# Altium schematic sheet [7]Audio.SchDoc
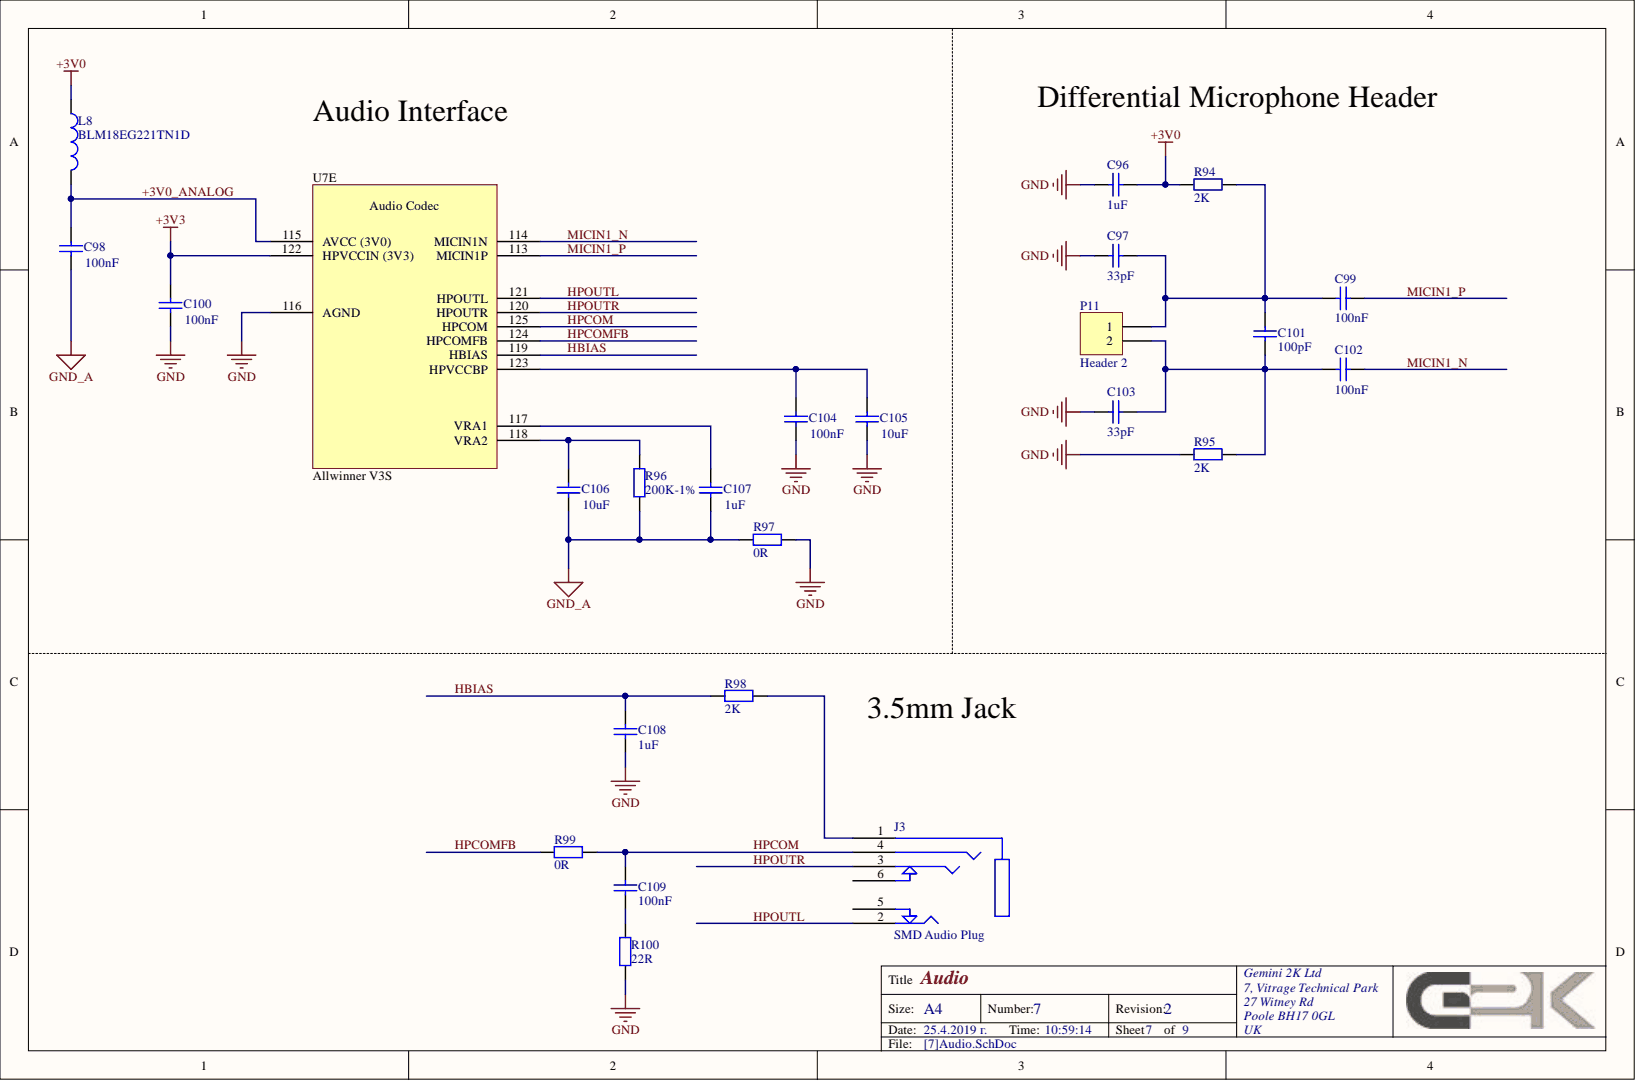
[diagram p0: [7]Audio.SchDoc]

## Parts
C96  value 1uF  [1608[0603]]  (hidden comment: 0603)
C97  value 33pF  [1608[0603]]  (hidden comment: 0603)
C98  value 100nF  [1608[0603]]  (hidden comment: 0603)
C99  value 100nF  [1608[0603]]  (hidden comment: 0603)
C100  value 100nF  [1608[0603]]  (hidden comment: 0603)
C101  value 100pF  [1608[0603]]  (hidden comment: 0603)
C102  value 100nF  [1608[0603]]  (hidden comment: 0603)
C103  value 33pF  [1608[0603]]  (hidden comment: 0603)
C104  value 100nF  [1608[0603]]  (hidden comment: 0603)
C105  value 10uF  [1608[0603]]  (hidden comment: 0603)
C106  value 10uF  [1608[0603]]  (hidden comment: 0603)
C107  value 1uF  [1608[0603]]  (hidden comment: 0603)
C108  value 1uF  [1608[0603]]  (hidden comment: 0603)
C109  value 100nF  [1608[0603]]  (hidden comment: 0603)
J3  SMD Audio Plug  [SJ-43516-SMT]
L8  BLM18EG221TN1D  [1608[0603]]
P11  Header 2  [HDR1X2]
R94  value 2K  [J1-0603]  (hidden comment: 0603)
R95  value 2K  [J1-0603]  (hidden comment: 0603)
R96  value 200K-1%  [J1-0603]  (hidden comment: 0603)
R97  value 0R  [J1-0603]  (hidden comment: 0603)
R98  value 2K  [J1-0603]  (hidden comment: 0603)
R99  value 0R  [J1-0603]  (hidden comment: 0603)
R100  value 22R  [J1-0603]  (hidden comment: 0603)
U7  Allwinner V3S  Manufacturer Name: Allwinner  Manufacturer Part No.: V3S  [LQFP128 E-PAD - Low Density]  (part E)

## Nets
+3V0: C96.2 L8.1 R94.2
+3V3: C100.2 U7.122(HPVCCIN_(3V3))
GND: C96.1 C97.1 C100.1 C103.1 C104.1 C105.1 C108.1 R95.2 R97.2 R100.2 U7.116(AGND)
GND_A: C98.1 C106.1 C107.1 R96.2 R97.1
+3V0_ANALOG: C98.2 L8.2 U7.115(AVCC_(3V0))
HBIAS: C108.2 R98.2 U7.119(HBIAS)
HPCOM: C109.2 J3.4 R99.1 U7.125(HPCOM)
HPCOMFB: R99.2 U7.124(HPCOMFB)
HPOUTL: J3.2 U7.121(HPOUTL)
HPOUTR: J3.3 U7.120(HPOUTR)
MICIN1_N: C102.1 U7.114(MICIN1N)
MICIN1_P: C99.1 U7.113(MICIN1P)
NetC97_2: C97.2 C99.2 C101.1 P11.1 R94.1
NetC101_2: C101.2 C102.2 C103.2 P11.2 R95.1
NetC104_2: C104.2 C105.2 U7.123(HPVCCBP)
NetC106_2: C106.2 R96.1 U7.118(VRA2)
NetC107_2: C107.2 U7.117(VRA1)
NetC109_1: C109.1 R100.1
NetJ3_1: J3.1 R98.1

Not connected: J3 5 6

## Library data of the parts
C96 C97 C101 C103 C105-C108 = Cap: Capacitor; Case Code (in): 0603; Component Kind: Standard; LatestRevisionDate: 17-Jul-2002; LatestRevisionNote: Re-released for DXP Platform.; Library Name: Miscellaneous Devices.IntLib; PackageDescription: Radial Cap, Thru-Hole; 2 Leads; 0.3 in Pin Spacing; PackageReference: RAD-0.3; Published: 8-Jun-2000; Signal Integrity: Cap; Simulation: CAP; Publisher: Altium Limited
  C96 C107 C108: Distributor Link: https://eu.mouser.com/ProductDetail/Taiyo-Yuden/LMK107B7105KA-T?qs=sGAEpiMZZMvsSlwiRhF8qgJCQaFFkNE%2fsetabqB5pzo%3d
  C97 C103: Distributor Link: https://uk.farnell.com/avx/06035a330jat2a/cap-33pf-50v-5-c0g-np0-0603/dp/498555
  C101: Distributor Link: https://uk.farnell.com/multicomp/mcu0603c101jct/cap-100pf-50v-5-c0g-np0-0603/dp/9406115
  C105 C106: Distributor Link: https://eu.mouser.com/ProductDetail/Taiyo-Yuden/JMK107ABJ106MA-T?qs=sGAEpiMZZMvsSlwiRhF8qtYrDJZp5VWM0KJHW32Kpw4hdLhJsFnoCA%3d%3d
C98-C100 C102 C104 C109 = Cap: Capacitor; Note: We have them in Bulgaria; Case Code (in): 0603; Component Kind: Standard; Distributor Link: http://uk.farnell.com/kemet/c0603x104k4ractu/cap-mlcc-x7r-100nf-16v-0603/dp/1414027; LatestRevisionDate: 17-Jul-2002; LatestRevisionNote: Re-released for DXP Platform.; Library Name: Miscellaneous Devices.IntLib; PackageDescription: Radial Cap, Thru-Hole; 2 Leads; 0.3 in Pin Spacing; PackageReference: RAD-0.3; Published: 8-Jun-2000; Signal Integrity: Cap; Simulation: CAP; Publisher: Altium Limited
J3 = SJ-43516-SMT: Manufacturer Name: CUI; Manufacturer Part No.: SJ-43514-SMT-TR; Component Kind: Standard; Description: SMD Audio Plug; Distributor Link: https://eu.mouser.com/ProductDetail/CUI/SJ-43514-SMT-TR?qs=WyjlAZoYn528%252BiAb5RzVtg%3D%3D; Library Name: PapayaPi.SchLib
L8 = Inductor: Manufacturer Name: Murata; Component Kind: Standard; Description: Inductor; Distributor Link: http://uk.farnell.com/murata/blm18eg221tn1d/ferrite-bead-0-15ohm-1a-0603/dp/1515717; Library Name: Miscellaneous Devices.IntLib; Manufacturer Part No.: BLM18EG221TN1D; Signal Integrity: Inductor; Simulation: INDUCTOR
P11 = Header 2: Header, 2-Pin; Component Kind: Standard; LatestRevisionDate: 17-Jul-2002; LatestRevisionNote: Re-released for DXP Platform.; Library Name: Miscellaneous Connectors.IntLib; Signal Integrity: Connector; Publisher: Altium Limited
R94-R100 = Res2: Resistor; Note: We have them in Bulgaria; Case Code (in): 0603; Component Kind: Standard; LatestRevisionDate: 17-Jul-2002; LatestRevisionNote: Re-released for DXP Platform.; Library Name: Miscellaneous Devices.IntLib; PackageDescription: Axial Device, Thru-Hole; 2 Leads; 0.4 in Pin Spacing; PackageReference: AXIAL-0.4; Published: 8-Jun-2000; Signal Integrity: Res; Simulation: RESISTOR; Publisher: Altium Limited
  R94 R95 R98: Distributor Link: https://uk.farnell.com/multicomp/mcwr06x2001ftl/res-2k-1-0-1w-0603-thick-film/dp/2447319
  R96: Distributor Link: https://uk.farnell.com/vishay/crcw0603200kfkea/res-200k-1-0-1w-0603-thick-film/dp/1469776
  R97 R99: Distributor Link: http://uk.farnell.com/yageo/rc0603fr-070rl/res-thick-film-0r-1-0-1w-0603/dp/2309106
  R100: Distributor Link: https://uk.farnell.com/multicomp/mcwr06x22r0ftl/res-22r-1-0-1w-thick-film/dp/2447301
U7 = Allwinner V3S: Component Kind: Standard; Library Name: Allwinner.SchLib
